# Altium schematic sheet UVEEC LED Cube.SchDoc("UVEEC LED Cube")
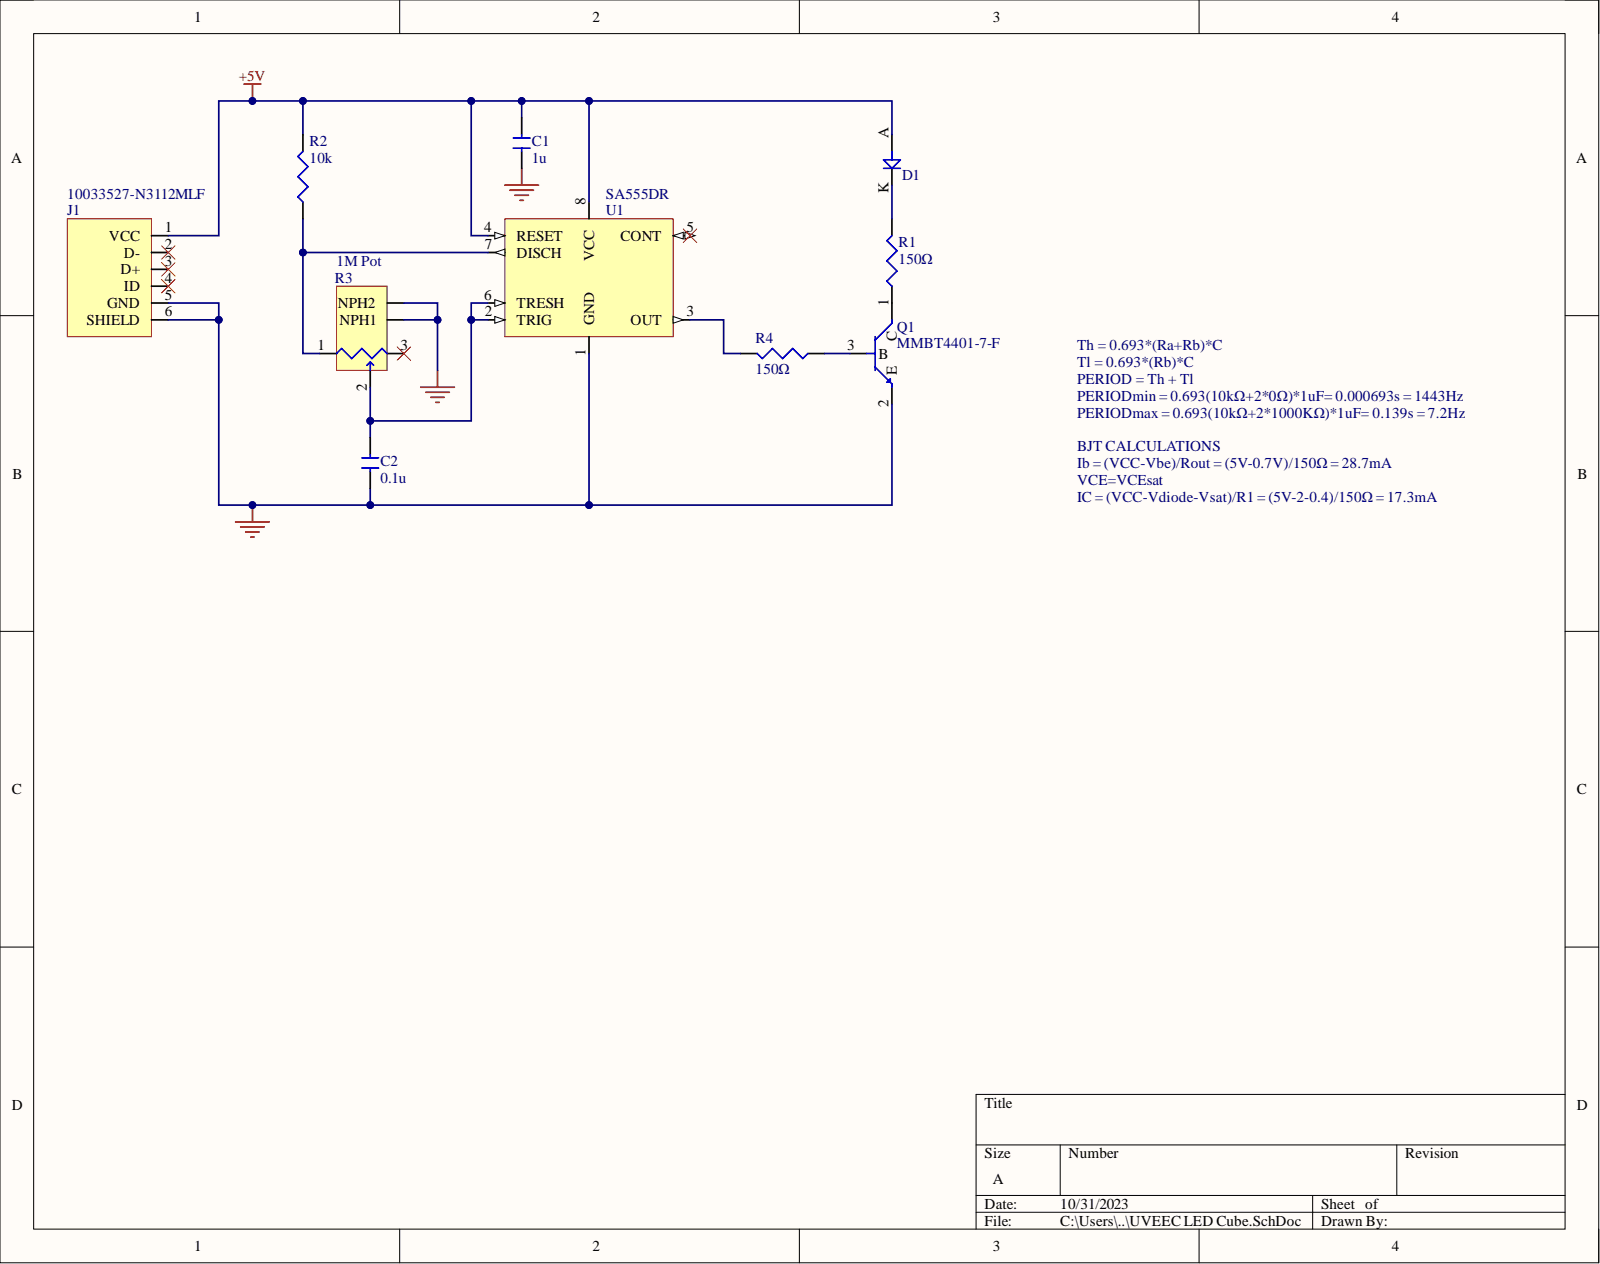
[diagram p0: UVEEC LED Cube.SchDoc]

## Parts
C1  1u  [Capacitor 1206]
C2  0.1u  [Capacitor 1206]
D1  HL3P-BF60-C00DD  [Diode TH D 1.05 P 2.54]  (value not drawn)
J1  10033527-N3112MLF  [10033527-N3112MLF]
Q1  MMBT4401-7-F  [SOT-23-3]
R1  150Ω  [Resistor 1206]
R2  10k  [Resistor 1206]
R3  1M Pot  [PDB12-H4301-105BF]
R4  150Ω  [Resistor 1206]
U1  SA555DR  [SOIC-8]

## Nets
+5V: C1.1 D1.A J1.1(VCC) R2.2 U1.4(RESET) U1.8(VCC)
GND: C1.2 C2.2 J1.5(GND) J1.6(SHIELD) Q1.2 R3.NPH1 R3.NPH2 U1.1(GND)
NetC2_1: C2.1 R3.2 U1.2(TRIG) U1.6(TRESH)
NetD1_K: D1.K R1.2
NetQ1_1: Q1.1 R1.1
NetQ1_3: Q1.3 R4.1
NetR2_1: R2.1 R3.1 U1.7(DISCH)
NetR4_2: R4.2 U1.3(OUT)

Not connected: J1 2(D-) 3(D+) 4(ID); R3 3; U1 5(CONT)

## Library data of the parts
C1 = CL31B105KAHNFNE: Cap Ceramic 1uF 25V X7R 10% SMD 1206 125°C Embossed T/R; Manufacturer: Samsung; Case Code (Imperial): 1206; Case Code (Metric): 3216; Case/Package: 1206; Category: Ceramic Capacitors; Component Kind: Standard; ComponentLink1Description: https://datasheet.ciiva.com/25731/cl31b105kahnfne-25731284.pdf?src-supplier=Digi-Key; ComponentLink1URL: https://datasheet.ciiva.com/25731/cl31b105kahnfne-25731284.pdf?src-supplier=Digi-Key; Construction: Flat; Depth: 1.6mm; Dielectric: X7R; Height: 1.6mm; Length: 3.2mm; Manufacturer Part Number: CL31B105KAHNFNE; Max Operating Temperature: 125°C; Min Operating Temperature: -55°C; Mounting Technology: Surface Mount; Packaging: Tape and Reel; Pricing: 1=0.13, 10=0.094, 50=0.0534, 100=0.0443, 500=0.03106, 1000=0.02571 (USD); Radiation Hardening: No; RoHS: Yes; RoHS Compliant: Yes; Series: CL; Stock: 266403; Supplier: Digi-Key; Supplier Part Number: 1276-3086-1-ND; Tolerance: 10%; Value: 1uF; Voltage Rating: 25V; Library Name: UVEEC LED Cube.SchLib
C2 = CL31B104KBCNNNC: Multilayer Ceramic Capacitor, 0.1 uF, 50 V, ± 10%, X7R, 1206 [3216 Metric]; Manufacturer: Samsung; Value: 100nF; Voltage: 50V; Case Code (Imperial): 1206; Case Code (Metric): 3216; Case/Package: 1206; Category: Ceramic Capacitors; Component Kind: Standard; ComponentLink1Description: https://datasheet.ciiva.com/25710/cl31b104kbcnnnc-25710293.pdf?src-supplier=Digi-Key; ComponentLink1URL: https://datasheet.ciiva.com/25710/cl31b104kbcnnnc-25710293.pdf?src-supplier=Digi-Key; ComponentLink2Description: https://datasheet.ciiva.com/29201/451941-da-01-en-vs-kondensator-1206-29201689.pdf?src-supplier=Conrad; ComponentLink2URL: https://datasheet.ciiva.com/29201/451941-da-01-en-vs-kondensator-1206-29201689.pdf?src-supplier=Conrad; ComponentLink3Description: https://datasheet.ciiva.com/16298/cl05b104kq5nnnc-16298186.pdf?src-supplier=Future+Electronics; ComponentLink3URL: https://datasheet.ciiva.com/16298/cl05b104kq5nnnc-16298186.pdf?src-supplier=Future+Electronics; Depth: 1.6mm; Dielectric: X7R; Height: 0.85mm; Lead Free: Lead Free; Length: 3.2mm; Manufacturer Part Number: CL31B104KBCNNNC; Material: Ceramic; Max Operating Temperature: 125°C; Min Operating Temperature: -55°C; Mounting Technology: Surface Mount; Packaging: Tape and Reel; Pricing: 1=0.11, 10=0.078, 50=0.0444, 100=0.0368, 500=0.02582, 1000=0.02137 (USD); RoHS: Yes; RoHS Compliant: Yes; Series: CL; Stock: 760991; Supplier: Digi-Key; Supplier Part Number: 1276-1017-1-ND; Tolerance: 10%; Voltage Rating: 50V; Voltage Rating (DC): 50V; Library Name: UVEEC LED Cube.SchLib
D1 = HL3P-BF60-C00DD: LED Yellow Green 570nm 20mA 2-Pin Through Hole T/R; Manufacturer: Broadcom; Component Kind: Standard; Manufacturer Part Number: HL3P-BF60-C00DD; Pricing: 1=0.58, 10=0.384, 100=0.2541, 1000=0.19616 (USD); RoHS: No; Stock: 26786; Supplier: Digi-Key; Supplier Part Number: 516-HL3P-BF60-C00DDCT-ND; Library Name: UVEEC LED Cube.SchLib
J1 = 10033527-N3112MLF: MINI USB 2.0- AB Type Receptacle conn. Right Angle Surface Mount Type; Manufacturer: Amphenol ICC / FCI; Gender: Female; Number of Ports: 1; Pitch: 0.8mm; Category: Connectors; Component Kind: Standard; ComponentLink1Description: https://datasheet.ciiva.com/3094/10033527-3094701.pdf?src-supplier=Avnet; ComponentLink1URL: https://datasheet.ciiva.com/3094/10033527-3094701.pdf?src-supplier=Avnet; Contact Material: Copper Alloy; Contact Plating: Gold; Depth: 9.2mm; Housing Material: Polymer; Length: 9.9mm; Manufacturer Part Number: 10033527-N3112MLF; Mounting Technology: Surface Mount; Orientation: Right Angle; Package Quantity: 750; Packaging: Tape and Reel; Pricing: 1=0.51, 10=0.446, 25=0.4076, 50=0.3838, 100=0.3677, 250=0.33576 (USD); RoHS: Yes; RoHS Compliant: Yes; Stock: 11754; Supplier: Digi-Key; Supplier Part Number: 609-10033527-N3112MLFCT-ND; Termination: Solder; Library Name: UVEEC LED Cube.SchLib
Q1 = MMBT4401-7-F: NPN Ic=600mA Vceo=40V hfe=100~300 fT=250MHz P=310mW SOT-23; Manufacturer: Diodes; Clock Frequency: 250MHz; Collector Base Voltage (VCBO): 60V; Collector Emitter Breakdown Voltage: 40V; Collector Emitter Saturation Voltage: 750mV; Continuous Collector Current: 600mA; Emitter Base Voltage (VEBO): 6V; hFE Min: 100; Max Power Dissipation: 300mW; Number of Elements: 1; Power Dissipation: 310mW; Case/Package: SOT-23; Category: BJTs; Collector Emitter Voltage (VCEO): 40V; Collector-emitter Voltage-Max: 750mV; Component Kind: Standard; ComponentLink1Description: https://datasheet.ciiva.com/15139/getdatasheetpartid-91436-15139165.pdf?src-supplier=Verical; ComponentLink1URL: https://datasheet.ciiva.com/15139/getdatasheetpartid-91436-15139165.pdf?src-supplier=Verical; ComponentLink2Description: https://datasheet.ciiva.com/972/ds30039-972547.pdf?src-supplier=Digi-Key; ComponentLink2URL: https://datasheet.ciiva.com/972/ds30039-972547.pdf?src-supplier=Digi-Key; ComponentLink3Description: https://datasheet.ciiva.com/972/ds30039-972548.pdf?src-supplier=Digi-Key; ComponentLink3URL: https://datasheet.ciiva.com/972/ds30039-972548.pdf?src-supplier=Digi-Key; ComponentLink4Description: https://datasheet.ciiva.com/972/ds30039-972549.pdf?src-supplier=Digi-Key; ComponentLink4URL: https://datasheet.ciiva.com/972/ds30039-972549.pdf?src-supplier=Digi-Key; ComponentLink5Description: https://datasheet.ciiva.com/16199/ds30039-16199054.pdf?src-supplier=Future+Electronics; ComponentLink5URL: https://datasheet.ciiva.com/16199/ds30039-16199054.pdf?src-supplier=Future+Electronics; ComponentLink6Description: https://datasheet.ciiva.com/11201/ds30039-11201820.pdf?src-supplier=Avnet; ComponentLink6URL: https://datasheet.ciiva.com/11201/ds30039-11201820.pdf?src-supplier=Avnet; Frequency: 250MHz; Gain Bandwidth Product: 250MHz; Height: 1mm; Lead Free: Lead Free; Length: 3.05mm; Manufacturer Part Number: MMBT4401-7-F; Max Breakdown Voltage: 40V; Max Collector Current: 600mA; Max Operating Temperature: 150°C; Min Operating Temperature: -55°C; Mounting Technology: Surface Mount; Package Quantity: 3000; Packaging: Tape and Reel; Pins: 3; Polarity: NPN; Pricing: 1=0.14, 10=0.098, 100=0.0528, 500=0.04146, 1000=0.02878 (USD); Radiation Hardening: No; Reach SVHC Compliant: Yes; RoHS: Yes; RoHS Compliant: Yes; Series: Automotive, AEC-Q101; Stock: 950358; Supplier: Digi-Key; Supplier Part Number: MMBT4401-FDICT-ND; Transition Frequency: 250MHz; Voltage Rating (DC): 40V; Weight: 0.000282oz; Width: 1.4mm; Library Name: UVEEC LED Cube.SchLib
R1 R4 = RMCF1206FG150R: RES 150 OHM 1% 1/4W 1206; Manufacturer: Stackpole Electronics; Max Power Dissipation: 250mW; Temperature Coefficient: 100ppm/°C; Value: 150R; Case Code (Imperial): 1206; Case Code (Metric): 3216; Case/Package: 1206; Category: Chip SMD Resistors; Component Kind: Standard; ComponentLink1Description: https://www.seielect.com/catalog/SEI-RMCF_RMCP.pdf?src-supplier=Avnet; ComponentLink1URL: https://www.seielect.com/catalog/SEI-RMCF_RMCP.pdf?src-supplier=Avnet; ComponentLink2Description: https://www.seielect.com/catalog/sei-rmcf_rmcp.pdf?src-supplier=Digi-Key; ComponentLink2URL: https://www.seielect.com/catalog/sei-rmcf_rmcp.pdf?src-supplier=Digi-Key; ComponentLink3Description: https://www.seielect.com/catalog/SEI-Packaging.pdf?src-supplier=Digi-Key; ComponentLink3URL: https://www.seielect.com/catalog/SEI-Packaging.pdf?src-supplier=Digi-Key; Contact Plating: Tin,Matte; Features: Automotive AEC-Q200; Manufacturer Part Number: RMCF1206FG150R; Max Operating Temperature: 155°C; Min Operating Temperature: -55°C; Mounting Technology: Surface Mount; Number of Terminations: 2; Packaging: Tape and Reel; Pricing: 1=0.1, 10=0.023, 50=0.0146, 100=0.0122, 500=0.00832, 1000=0.00718, 5000=0.00526 (USD); Radiation Hardening: No; Ratings: AEC-Q200; RoHS: Yes; RoHS Compliant: Yes; Series: RMCF; Stock: 105204; Supplier: Digi-Key; Supplier Part Number: RMCF1206FG150RCT-ND; Tolerance: 1%; Library Name: UVEEC LED Cube.SchLib
R2 = RMCF1206FT10K0: Res Thick Film 1206 10K Ohm 1% 0.25W ±100ppm/°C Molded SMD Paper T/R; Manufacturer: Stackpole Electronics; Max Power Dissipation: 250mW; Value: 10kR; Voltage Rating: 200V; Case Code (Imperial): 1206; Case Code (Metric): 3216; Case/Package: 1206; Category: Chip SMD Resistors; Component Kind: Standard; ComponentLink1Description: https://datasheet.ciiva.com/15193/getdatasheetpartid-369489-15193659.pdf?src-supplier=Verical; ComponentLink1URL: https://datasheet.ciiva.com/15193/getdatasheetpartid-369489-15193659.pdf?src-supplier=Verical; Construction: Rectangular; Contact Plating: Tin,Matte; Depth: 1.6mm; Features: Automotive AEC-Q200; Height: 0.028inch; Lead Free: Lead Free; Length: 3.2mm; Manufacturer Part Number: RMCF1206FT10K0; Max Operating Temperature: 155°C; Military Standard: Not; Min Operating Temperature: -55°C; Mounting Technology: Surface Mount; Number of Terminations: 2; Packaging: Tape and Reel; Power Rating: 250mW; Pricing: 1=0.1, 10=0.023, 50=0.0146, 100=0.0122, 500=0.00832, 1000=0.00718 (USD); Radiation Hardening: No; Ratings: AEC-Q200; RoHS: Yes; RoHS Compliant: Yes; Series: RMCF; Stock: 1414203; Supplier: Digi-Key; Supplier Part Number: RMCF1206FT10K0CT-ND; Temperature Coefficient: 100ppm/°C; Tolerance: 1%; Width: 0.063inch; Library Name: UVEEC LED Cube.SchLib
R3 = PDB12-H4301-105BF: Res Carbon Film POT 1M Ohm 20% 2/25W 1(Elec)/1(Mech)Turn 6mm (12.4 X 34.6mm) Pin Bracket Mount/Through Hole; Manufacturer: Bourns; Max Power Dissipation: 80mW; Number of Gangs: 1; Value: 1MR; Category: Variable Resistors and Potentiometers; Component Kind: Standard; ComponentLink1Description: https://datasheet.ciiva.com/26913/getdatasheetpartid-380329-26913658.pdf?src-supplier=Verical; ComponentLink1URL: https://datasheet.ciiva.com/26913/getdatasheetpartid-380329-26913658.pdf?src-supplier=Verical; ComponentLink2Description: https://datasheet.ciiva.com/8702/pdb12-8702733.pdf?src-supplier=Avnet; ComponentLink2URL: https://datasheet.ciiva.com/8702/pdb12-8702733.pdf?src-supplier=Avnet; Depth: 12.4mm; Length: 12.4mm; Manufacturer Part Number: PDB12-H4301-105BF; Max Operating Temperature: 50°C; Military Standard: Not; Min Operating Temperature: -10°C; Mounting Technology: Through Hole,Brackets; Number of Turns: 1; Package Quantity: 100; Packaging: Bulk; Power Rating: 80mW; Pricing: 1=1.28, 10=1.133, 25=1.02, 50=0.9822, 100=0.9444, 250=0.88768, 500=0.75548, 1000=0.69882, 5000=0.57416 (USD); Radiation Hardening: No; Resistor Type: Carbon; RoHS: Yes; RoHS Compliant: Yes; Series: PDB12; Stock: 887; Supplier: Digi-Key; Supplier Part Number: PDB12-H4301-105BF-ND; Taper: Linear; Technology: CARBON FILM; Termination: Pin; Tolerance: 20%; Type: Potentiometer; Library Name: UVEEC LED Cube.SchLib
U1 = SA555DR: IC OSC SGL TIMER 100KHZ 8-SOIC; Manufacturer: Texas Instruments; High Level Output Current: -200mA; Low Level Output Current: 200mA; Max Supply Voltage: 16V; Min Supply Voltage: 4.5V; Number of Timers/Counters: 1; Operating Supply Current: 10mA; Quiescent Current: 15mA; Supply Current: 2mA; Case/Package: SOIC; Category: Timers and Oscillators; Component Kind: Standard; ComponentLink1Description: https://datasheet.ciiva.com/34303/1998263-34303726.pdf?src-supplier=Jameco; ComponentLink1URL: https://datasheet.ciiva.com/34303/1998263-34303726.pdf?src-supplier=Jameco; ComponentLink2Description: https://datasheet.ciiva.com/1592/1748907-1592972.pdf?src-supplier=Newark; ComponentLink2URL: https://datasheet.ciiva.com/1592/1748907-1592972.pdf?src-supplier=Newark; ComponentLink3Description: https://datasheet.ciiva.com/46413/1834004-46413322.pdf?src-supplier=Element14; ComponentLink3URL: https://datasheet.ciiva.com/46413/1834004-46413322.pdf?src-supplier=Element14; ComponentLink4Description: https://datasheet.ciiva.com/1233/sa555-1233135.pdf?src-supplier=Digi-Key; ComponentLink4URL: https://datasheet.ciiva.com/1233/sa555-1233135.pdf?src-supplier=Digi-Key; ComponentLink5Description: https://datasheet.ciiva.com/1233/sa555-1233134.pdf?src-supplier=Digi-Key; ComponentLink5URL: https://datasheet.ciiva.com/1233/sa555-1233134.pdf?src-supplier=Digi-Key; ComponentLink6Description: https://datasheet.ciiva.com/1233/sa555-1233136.pdf?src-supplier=Digi-Key; ComponentLink6URL: https://datasheet.ciiva.com/1233/sa555-1233136.pdf?src-supplier=Digi-Key; ComponentLink7Description: https://datasheet.ciiva.com/14274/se555m-14274469.pdf?src-supplier=Avnet; ComponentLink7URL: https://datasheet.ciiva.com/14274/se555m-14274469.pdf?src-supplier=Avnet; ComponentLink8Description: https://datasheet.ciiva.com/15152/getdatasheetpartid-247856-15152619.pdf?src-supplier=Verical; ComponentLink8URL: https://datasheet.ciiva.com/15152/getdatasheetpartid-247856-15152619.pdf?src-supplier=Verical; Frequency: 100kHz; Lead Free: Lead Free; Manufacturer Part Number: SA555DR; Max Operating Temperature: 85°C; Min Operating Temperature: -40°C; Mounting Technology: Surface Mount; Package Quantity: 2500; Packaging: Tape and Reel; Pins: 8; Pricing: 1=0.38, 10=0.31, 25=0.2836, 100=0.2109, 250=0.19116, 500=0.1582, 1000=0.11864 (USD); Radiation Hardening: No; Reach SVHC Compliant: No; RoHS: Yes; RoHS Compliant: Yes; Series: -; Stock: 21612; Supplier: Digi-Key; Supplier Part Number: 296-14635-1-ND; Weight: 0.002561oz; Library Name: UVEEC LED Cube.SchLib
